# Altium schematic sheet MCU_Signals.SchDoc(MCU_Signals)
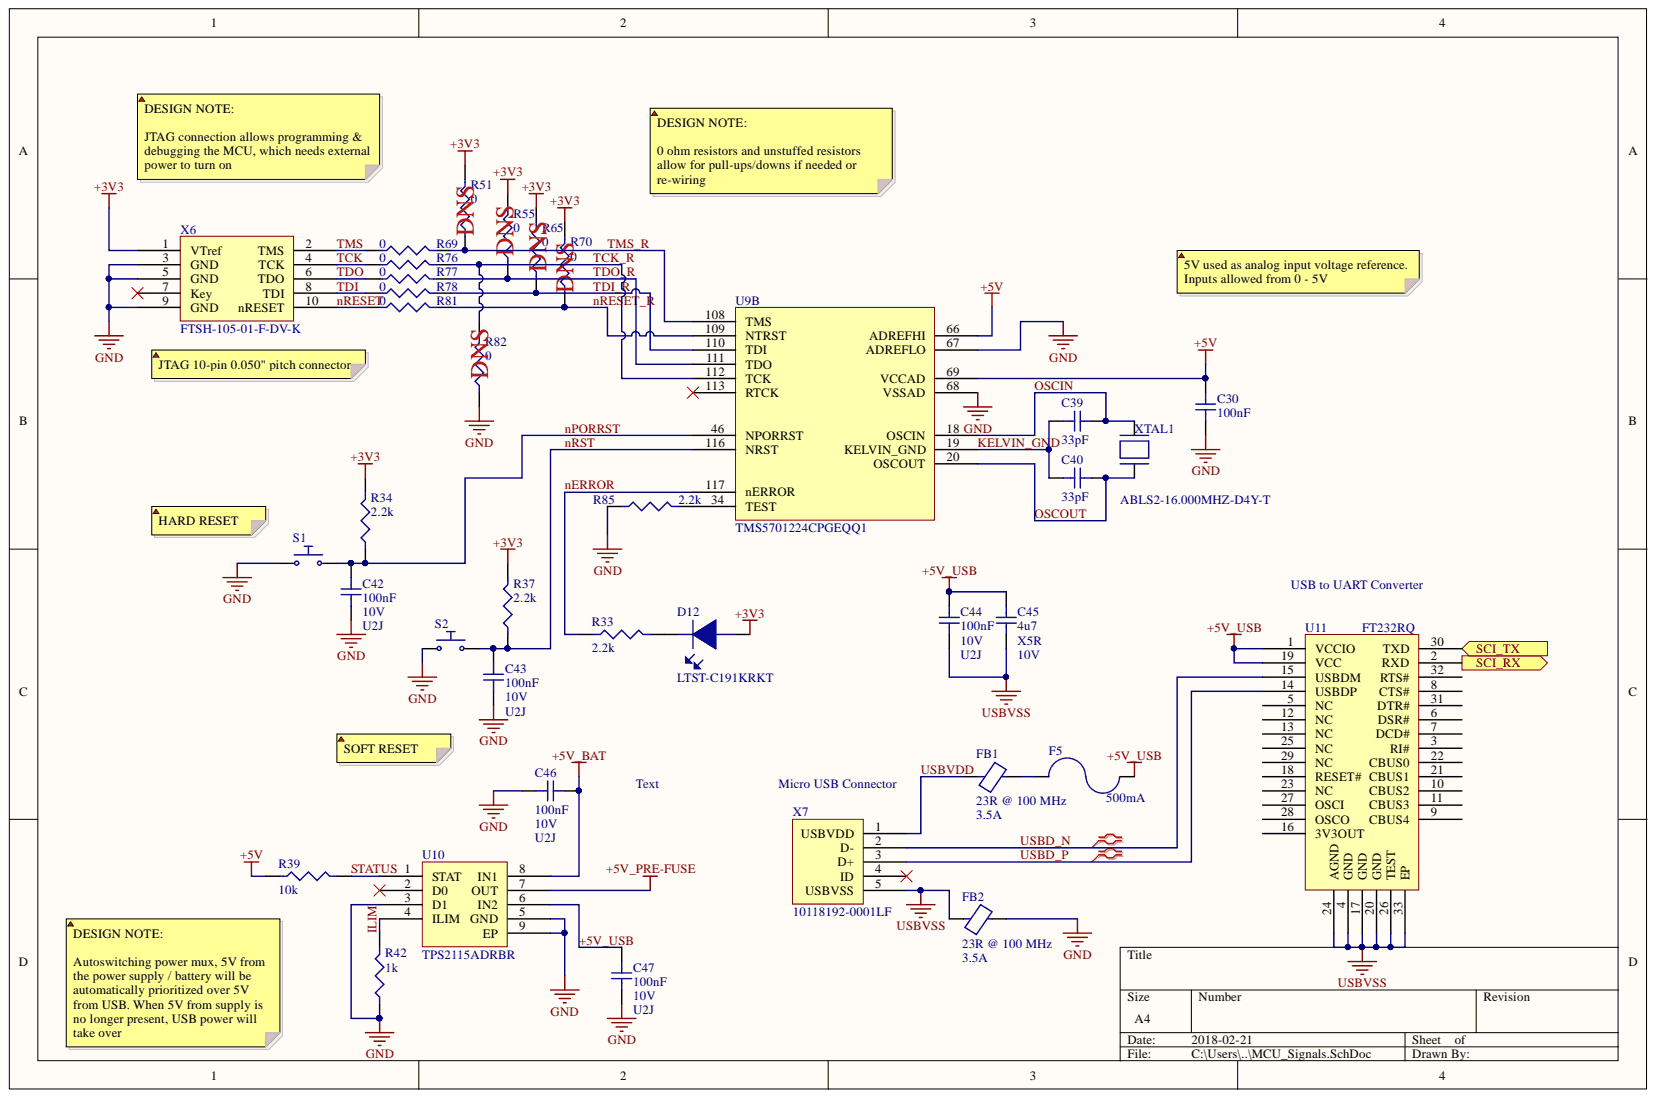
[diagram p0: MCU_Signals.SchDoc]

## Parts
C30  100nF  [C0805]
C39  33pF  [C0805]
C40  33pF  [C0805]
C42  100nF  Voltage Rating (DC): 10V  Dielectric: U2J  [C0805]
C43  100nF  Voltage Rating (DC): 10V  Dielectric: U2J  [C0805]
C44  100nF  Voltage Rating (DC): 10V  Dielectric: U2J  [C0805]
C45  4u7  Voltage Rating (DC): 10V  Dielectric: X5R  [C0805]
C46  100nF  Voltage Rating (DC): 10V  [C0805]
C47  100nF  Voltage Rating (DC): 10V  Dielectric: U2J  [C0805]
D12  LTST-C191KRKT  [LED_0603]
F5  500mA  [FUSE_0603]
FB1  23R @ 100 MHz  Max DC Current: 3.5A  Impedance: 23R  [FB_1806]
FB2  23R @ 100 MHz  Max DC Current: 3.5A  Impedance: 23R  [FB_1806]
R33  2.2k  [R0805]
R34  2.2k  [R0805]
R37  2.2k  [R0805]
R39  10k  [R0805]
R42  1k  [R0805]
R51  0  [R0805]
R55  0  [R0805]
R65  0  [R0805]
R69  0  [R0805]
R70  0  [R0805]
R76  0  [R0805]
R77  0  [R0805]
R78  0  [R0805]
R81  0  [R0805]
R82  0  [R0805]
R85  2.2k  [R0805]
S1  PTS645SM43SMTR92LFS  [PUSH_BUTTON]  (value not drawn)
S2  PTS645SM43SMTR92LFS  [PUSH_BUTTON]  (value not drawn)
U9  TMS5701224CPGEQQ1  [LQFP-144]  (part B)
U10  TPS2115ADRBR  [VSON-8]
U11  FT232RQ  [QFN-32]
X6  FTSH-105-01-F-DV-K  [JTAG_50mil_10pin]
X7  10118192-0001LF  [USB_MICRO]
XTAL1  ABLS2-16.000MHZ-D4Y-T  [XTAL]

## Ports
SCI_RX, SCI_TX

## Nets
+3V3: D12.1 R34.2 R37.2 R51.2 R55.2 R65.2 R70.2 X6.1(VTref)
+5V: C30.2 R39.1 U9.66(ADREFHI) U9.69(VCCAD)
+5V_BAT: C46.2 U10.8(IN1)
+5V_PRE-FUSE: U10.7(OUT)
GND: C30.1 C42.1 C43.1 C46.1 C47.2 FB2.2 R42.1 R82.1 R85.1 S1.1 S2.1 U9.67(ADREFLO) U9.68(VSSAD) U10.3(D1) U10.5(GND) U10.9(EP) X6.3(GND) X6.5(GND) X6.9(GND)
USBVSS: C44.1 C45.1 FB2.1 U11.4(GND) U11.17(GND) U11.20(GND) U11.24(AGND) U11.26(TEST) U11.33(EP) X7.5(USBVSS)
+5V_USB: C44.2 C45.2 C47.1 F5.2 U10.6(IN2) U11.1(VCCIO) U11.19(VCC)
ILIM: R42.2 U10.4(ILIM)
KELVIN_GND: C39.1 C40.1 U9.19(KELVIN_GND)
nERROR: R33.1 U9.117(nERROR)
nPORRST: C42.2 R34.1 S1.2 U9.46(NPORRST)
nRESET: R81.1 X6.10(nRESET)
nRESET_R: R70.1 R81.2 U9.109(NTRST)
nRST: C43.2 R37.1 S2.2 U9.116(NRST)
OSCIN: C39.2 U9.18(OSCIN) XTAL1.1
OSCOUT: C40.2 U9.20(OSCOUT) XTAL1.2
SCI_RX: U11.2(RXD) ; port SCI_RX
SCI_TX: U11.30(TXD) ; port SCI_TX
STATUS: R39.2 U10.1(STAT)
TCK: R76.1 X6.4(TCK)
TCK_R: R76.2 R82.2 U9.112(TCK)
TDI: R78.1 X6.8(TDI)
TDI_R: R65.1 R78.2 U9.110(TDI)
TDO: R77.1 X6.6(TDO)
TDO_R: R55.1 R77.2 U9.111(TDO)
TMS: R69.1 X6.2(TMS)
TMS_R: R51.1 R69.2 U9.108(TMS)
USBD_N: U11.15(USBDM) X7.2(D-)
USBD_P: U11.14(USBDP) X7.3(D+)
USBVDD: FB1.1 X7.1(USBVDD)
NetD12_2: D12.2 R33.2
NetF5_1: F5.1 FB1.2
NetR85_2: R85.2 U9.34(TEST)

Not connected: U9 113(RTCK); U10 2(D0); U11 3(RI#) 5(NC) 6(DSR#) 7(DCD#) 8(CTS#) 9(CBUS4) 10(CBUS2) 11(CBUS3) 12(NC) 13(NC) 16(3V3OUT) 18(RESET#) 21(CBUS1) 22(CBUS0) 23(NC) 25(NC) 27(OSCI) 28(OSCO) 29(NC) 31(DTR#) 32(RTS#); X6 7(Key); X7 4(ID)

## Library data of the parts
C30 = GRM21B7U1A104JA01L: CAP MLCC 100nF 10Volts U2J 5%; Manufacturer: Murata; Voltage Rating (DC): 10V; Width: 0.049inch; Voltage Rating: 10V; Tolerance: 5%; Thickness: 0.053inch; Termination: SMD/SMT; Supplier Part Number 1: 490-11592-6-ND; Supplier 1: Digi-Key; Stock 1: 6000; Radiation Hardening: No; Pricing 1: 1=0.46, 10=0.32, 100=0.192, 500=0.144, 1000=0.1216 (USD); Packaging: Tape and Reel; Package Quantity: 3000; Mount: Surface Mount; Min Operating Temperature: -55°C; Max Operating Temperature: 125°C; Manufacturer Part Number: GRM21B7U1A104JA01L; Length: 2mm; Height: 1.25mm; Dielectric: U2J; Depth: 1.25mm; ComponentLink3URL: https://datasheet.ciiva.com/11618/product-11618924.pdf; ComponentLink3Description: https://datasheet.ciiva.com/11618/product-11618924.pdf; ComponentLink2URL: https://datasheet.ciiva.com/17451/product-17451845.pdf; ComponentLink2Description: https://datasheet.ciiva.com/17451/product-17451845.pdf; ComponentLink1URL: https://datasheet.ciiva.com/5424/product-5424660.pdf; ComponentLink1Description: https://datasheet.ciiva.com/5424/product-5424660.pdf; Component Kind: Standard; Case Code (Metric): 2012; Case Code (Imperial): 0805; Case/Package: 0805; Capacitance: 100nF; Library Name: PHANTOM_LIBRARY.SchLib
C39 C40 = 885012007003: CAP MLCC 33pF 10V Ceramic Capacitor C0G, NP0 0805 (2012 Metric) 0.079" L x 0.049" W (2.00mm x 1.25mm); Manufacturer: Wurth Electronics; Tolerance: 5%; Width: 0.049inch; Voltage Rating: 10V; Thickness: 0.028inch; Supplier Part Number 1: 732-7808-1-ND; Supplier 1: Digi-Key; Stock 1: 3090; Pricing 1: 1=0.1, 50=0.068, 100=0.062, 250=0.056, 500=0.05, 1000=0.045 (USD); Packaging: Tape and Reel; Mount: Surface Mount; Min Operating Temperature: -55°C; Max Operating Temperature: 125°C; Manufacturer Part Number: 885012007003; Length: 0.079inch; Component Kind: Standard; Case Code (Metric): 2012; Case Code (Imperial): 0805; Case/Package: 0805; Capacitance: 33pF; Library Name: PHANTOM_LIBRARY.SchLib
C42-C44 C46 C47 = GRM21B7U1A104JA01L: CAP MLCC 100nF 10Volts U2J 5%; Manufacturer: Murata; Width: 0.049inch; Voltage Rating: 10V; Tolerance: 5%; Thickness: 0.053inch; Termination: SMD/SMT; Supplier Part Number 1: 490-11592-6-ND; Supplier 1: Digi-Key; Stock 1: 6000; Radiation Hardening: No; Pricing 1: 1=0.46, 10=0.32, 100=0.192, 500=0.144, 1000=0.1216 (USD); Packaging: Tape and Reel; Package Quantity: 3000; Mount: Surface Mount; Min Operating Temperature: -55°C; Max Operating Temperature: 125°C; Manufacturer Part Number: GRM21B7U1A104JA01L; Length: 2mm; Height: 1.25mm; Depth: 1.25mm; ComponentLink3URL: https://datasheet.ciiva.com/11618/product-11618924.pdf; ComponentLink3Description: https://datasheet.ciiva.com/11618/product-11618924.pdf; ComponentLink2URL: https://datasheet.ciiva.com/17451/product-17451845.pdf; ComponentLink2Description: https://datasheet.ciiva.com/17451/product-17451845.pdf; ComponentLink1URL: https://datasheet.ciiva.com/5424/product-5424660.pdf; ComponentLink1Description: https://datasheet.ciiva.com/5424/product-5424660.pdf; Component Kind: Standard; Case Code (Metric): 2012; Case Code (Imperial): 0805; Case/Package: 0805; Capacitance: 100nF; Library Name: PHANTOM_LIBRARY.SchLib
  C46: Dielectric: U2J
C45 = GRM219R61A475KE34D: CAP MLCC 4.7µF ±10% 10V X5R Ceramic Capacitor -55°C ~ 85°C Surface Mount, MLCC 0805 (2012 Metric) 0.079" L x 0.049" W (2.00mm x 1.25mm); Manufacturer: Murata; Capacitance: 4.7uF; Width: 0.049inch; Voltage Rating: 10V; Voltage: 10V; Tolerance: 10%; Thickness: 0.037inch; Termination: SMD/SMT; Supplier Part Number 1: 490-3339-6-ND; Supplier 1: Digi-Key; Stock 1: 251830; Pricing 1: 1=0.21, 10=0.152, 100=0.0716, 500=0.0502, 1000=0.0416 (USD); Packaging: Tape and Reel; Package Quantity: 4000; Mount: Surface Mount; Min Operating Temperature: -55°C; Max Operating Temperature: 85°C; Manufacturer Part Number: GRM219R61A475KE34D; Length: 2mm; Lead Free: Lead Free; Height: 0.85mm; Depth: 1.25mm; ComponentLink5URL: https://datasheet.ciiva.com/29542/product-29542668.pdf; ComponentLink5Description: https://datasheet.ciiva.com/29542/product-29542668.pdf; ComponentLink4URL: https://datasheet.ciiva.com/29542/product-29542667.pdf; ComponentLink4Description: https://datasheet.ciiva.com/29542/product-29542667.pdf; ComponentLink3URL: https://datasheet.ciiva.com/16467/product-16467258.pdf; ComponentLink3Description: https://datasheet.ciiva.com/16467/product-16467258.pdf; ComponentLink2URL: https://datasheet.ciiva.com/5414/product-5414984.pdf; ComponentLink2Description: https://datasheet.ciiva.com/5414/product-5414984.pdf; ComponentLink1URL: https://datasheet.ciiva.com/169/product-169527.pdf; ComponentLink1Description: https://datasheet.ciiva.com/169/product-169527.pdf; Component Kind: Standard; Case Code (Metric): 2012; Case Code (Imperial): 0805; Case/Package: 0805; Library Name: PHANTOM_LIBRARY.SchLib
D12 = LTST-C191KRKT: LED RED CLEAR 0603 SMD; Manufacturer: Vishay Lite-On; Wavelength: 639nm; Voltage: 2V; Viewing Angle: 130°; Test Current: 20mA; Reverse Voltage: 5V; Power Rating: 75mW; Number of LEDs: 1; Luminous Intensity: 54mcd; Lens Style: Clear,Diffused,Colorless,Rectangular; Lens Colour: Colorless; Forward Current: 30mA; Dominant Wavelength: 631nm; Width: 0.8mm; Supplier Part Number 1: 160-1447-1-ND; Supplier 1: Digi-Key; Stock 1: 684119; Radiation Hardening: No; Pricing 1: 1=0.29, 10=0.211, 25=0.1556, 100=0.1111, 250=0.0756, 500=0.0667, 1000=0.0522, 2500=0.0511 (USD); Power Dissipation: 75mW; Packaging: Tape and Reel; Package Quantity: 1; Number of Pins: 2; Number of Elements: 1; Mount: Surface Mount; Min Operating Temperature: -55°C; Max Operating Temperature: 85°C; Manufacturer Part Number: LTST-C191KRKT; Lens Transparency: Clear; Length: 1.6mm; Lead Free: Lead Free; Illumination Colour: Red; Height: 0.55mm; Forward Voltage: 2V; Depth: 0.8mm; ComponentLink7URL: https://datasheet.ciiva.com/12400/lite-on-ltst-c191krkt-190135-12400910.pdf; ComponentLink7Description: https://datasheet.ciiva.com/12400/lite-on-ltst-c191krkt-190135-12400910.pdf; ComponentLink6URL: https://datasheet.ciiva.com/15117/getdatasheetpartid-141922-15117737.pdf; ComponentLink6Description: https://datasheet.ciiva.com/15117/getdatasheetpartid-141922-15117737.pdf; ComponentLink5URL: https://datasheet.ciiva.com/2109/s-110-ltst-c191krkt-2109972.pdf; ComponentLink5Description: https://datasheet.ciiva.com/2109/s-110-ltst-c191krkt-2109972.pdf; ComponentLink4URL: https://datasheet.ciiva.com/16212/ltst-c191krkt-16212419.pdf; ComponentLink4Description: https://datasheet.ciiva.com/16212/ltst-c191krkt-16212419.pdf; ComponentLink3URL: https://datasheet.ciiva.com/2109/s-110-ltst-c191krkt-2109971.pdf; ComponentLink3Description: https://datasheet.ciiva.com/2109/s-110-ltst-c191krkt-2109971.pdf; ComponentLink2URL: https://datasheet.ciiva.com/2109/s-110-ltst-c191krkt-2109970.pdf; ComponentLink2Description: https://datasheet.ciiva.com/2109/s-110-ltst-c191krkt-2109970.pdf; ComponentLink1URL: https://datasheet.ciiva.com/11773/0900766b80dc02b2-11773601.pdf; ComponentLink1Description: https://datasheet.ciiva.com/11773/0900766b80dc02b2-11773601.pdf; Component Kind: Standard; Colour: Red; Case Code (Metric): 1608; Case Code (Imperial): 0603; Case/Package: 0603; Library Name: PHANTOM_LIBRARY.SchLib
F5 = ERB-RE0R50V: FUSE_0.5A SMD 0603, 0.5A; Manufacturer: Panasonic ECG; Voltage Rating (DC): 32V; Fuse Type: Board Mount (Cartridge Style Excluded); DC Cold Resistance: 330mR; Body Material: Alumina; Approvals: UL; Width: 0.8mm; Voltage Rating: 32V; Supplier Part Number 1: P15127CT-ND; Supplier 1: Digi-Key; Stock 1: 15715; REACH SVHC: No SVHC; Radiation Hardening: No; Pricing 1: 1=0.29, 5=0.284, 10=0.272, 50=0.2424, 100=0.2257, 250=0.2006, 500=0.1672, 1000=0.1588 (USD); Packaging: Tape and Reel; Package Quantity: 5000; Number of Pins: 2; Mount: Surface Mount; Min Operating Temperature: -40°C; Max Voltage Rating (DC): 32V; Max Operating Temperature: 125°C; Manufacturer Part Number: ERB-RE0R50V; Length: 1.6mm; Height: 0.54mm; Fuse Current: 500mA; Depth: 0.8mm; Current Rating: 500mA; Current: 500mA; ComponentLink9URL: https://datasheet.ciiva.com/46376/1328695-46376557.pdf; ComponentLink9Description: https://datasheet.ciiva.com/46376/1328695-46376557.pdf; ComponentLink8URL: https://datasheet.ciiva.com/30017/afa0000ce6-30017405.pdf; ComponentLink8Description: https://datasheet.ciiva.com/30017/afa0000ce6-30017405.pdf; ComponentLink7URL: https://datasheet.ciiva.com/46388/1328695-46388892.pdf; ComponentLink7Description: https://datasheet.ciiva.com/46388/1328695-46388892.pdf; ComponentLink6URL: https://datasheet.ciiva.com/5813/1328695-5813626.pdf; ComponentLink6Description: https://datasheet.ciiva.com/5813/1328695-5813626.pdf; ComponentLink5URL: https://datasheet.ciiva.com/11337/afa0000ce6-11337817.pdf; ComponentLink5Description: https://datasheet.ciiva.com/11337/afa0000ce6-11337817.pdf; ComponentLink4URL: https://datasheet.ciiva.com/25619/0900766b810c2f76-25619783.pdf; ComponentLink4Description: https://datasheet.ciiva.com/25619/0900766b810c2f76-25619783.pdf; ComponentLink3URL: https://datasheet.ciiva.com/299/afa0000ce6-299094.pdf; ComponentLink3Description: https://datasheet.ciiva.com/299/afa0000ce6-299094.pdf; ComponentLink2URL: https://datasheet.ciiva.com/299/afa0000ce6-299093.pdf; ComponentLink2Description: https://datasheet.ciiva.com/299/afa0000ce6-299093.pdf; ComponentLink1URL: https://datasheet.ciiva.com/299/afa0000ce6-299092.pdf; ComponentLink1Description: https://datasheet.ciiva.com/299/afa0000ce6-299092.pdf; Component Kind: Standard; Case Code (Metric): 1608; Case Code (Imperial): 0603; Case/Package: 0603; Library Name: PHANTOM_LIBRARY.SchLib
FB1 FB2 = FBMJ4516HL230NTV: FERRITE BEAD Ind Chip Bead Multi-Layer 23Ohm 30% 100MHz Ferrite 3.5A 1806 T/R; Manufacturer: Taiyo Yuden; Resistance: 180623R; Number of Lines: 1; DC Resistance (DCR): 14mR; Width: 1.6mm; Tolerance: 30%; Test Frequency: 100MHz; Termination: SMD/SMT; Supplier Part Number 1: 587-3857-1-ND; Supplier 1: Digi-Key; Stock 1: 1819; Pricing 1: 1=0.26, 10=0.202, 25=0.1676, 50=0.1444, 100=0.1299, 250=0.1097, 500=0.0982, 1000=0.0837 (USD); Packaging: Tape and Reel; Package Quantity: 2000; Mount: Surface Mount; Min Operating Temperature: -40°C; Max Operating Temperature: 125°C; Max Current Rating: 3.5A; Manufacturer Part Number: FBMJ4516HL230NTV; Length: 0.177inch; Height: 1.1mm; Depth: 1.6mm; Core Material: Ferrite; ComponentLink3URL: https://www.yuden.co.jp/ut/product/category/emisuppression/FBMJ4516HL230NTV_SS.pdf; ComponentLink3Description: https://www.yuden.co.jp/ut/product/category/emisuppression/FBMJ4516HL230NTV_SS.pdf; ComponentLink2URL: http://ds.yuden.co.jp/TYCOMPAS/ut/detail.do?productNo=FBMJ4516HL230NTV&fileName=FBMJ4516HL230NTV_SS&mode=specSheetDownload; ComponentLink2Description: http://ds.yuden.co.jp/TYCOMPAS/ut/detail.do?productNo=FBMJ4516HL230NTV&fileName=FBMJ4516HL230NTV_SS&mode=specSheetDownload; ComponentLink1URL: http://www.mouser.com/ds/2/396/chipbeads01_e-559265.pdf; ComponentLink1Description: http://www.mouser.com/ds/2/396/chipbeads01_e-559265.pdf; Component Kind: Standard; Case Code (Metric): 4516; Case Code (Imperial): 1806; Case/Package: 1806; Library Name: PHANTOM_LIBRARY.SchLib
R33 R34 R37 R85 = RC0805JR-072K2L: RES SMD 2.2K OHM 5% 1/8W; Manufacturer: Yageo; Voltage Rating: 150V; Temperature Coefficient: 100ppm/°C; Resistance: 2.2kR; Power Rating: 125mW; Tolerance: 5%; Termination: SMD/SMT; Supplier Part Number 1: 311-2.2KARCT-ND; Supplier 1: Digi-Key; Stock 1: 810523; REACH SVHC: Unknown; Radiation Hardening: No; Pricing 1: 1=0.1, 10=0.019, 100=0.0076, 1000=0.0034, 2500=0.003 (USD); Packaging: Tape and Reel; Min Operating Temperature: -55°C; Military Standard: Not; Max Operating Temperature: 155°C; Manufacturer Part Number: RC0805JR-072K2L; Length: 2mm; Lead Free: Lead Free; Depth: 1.25mm; Contact Plating: Tin,Lead,Matte; Composition: Thick Film; ComponentLink3URL: https://datasheet.ciiva.com/7941/442941-7941935.pdf; ComponentLink3Description: https://datasheet.ciiva.com/7941/442941-7941935.pdf; ComponentLink2URL: https://datasheet.ciiva.com/15190/getdatasheetpartid-251869-15190044.pdf; ComponentLink2Description: https://datasheet.ciiva.com/15190/getdatasheetpartid-251869-15190044.pdf; ComponentLink1URL: https://datasheet.ciiva.com/12667/rc0805-12667397.pdf; ComponentLink1Description: https://datasheet.ciiva.com/12667/rc0805-12667397.pdf; Component Kind: Standard; Case Code (Metric): 2012; Case Code (Imperial): 0805; Case/Package: 0805; Library Name: PHANTOM_LIBRARY.SchLib
R39 = RC0805FR-071KL: RES SMD 10K OHM 1% 1/8W 0805; Manufacturer: Yageo Phycomp; Voltage Rating (DC): 150V; Temperature Coefficient: 100ppm/°C; Resistance: 1KR; Power Rating: 125mW; Width: 1.25mm; Tolerance: 1%; Supplier 1: Digi-Key; Stock 1: 5527973; REACH SVHC: No SVHC; Pricing 1: 1=0.1, 10=0.022, 100=0.0088, 1000=0.004, 2500=0.0034 (USD); Packaging: Cut Tape; Number of Pins: 2; Min Operating Temperature: -55°C; Max Operating Temperature: 155°C; Manufacturer Part Number: RC0805FR-071KL; Length: 2mm; Lead Free: Lead Free; Height: 0.5mm; Depth: 1.25mm; Component Kind: Standard; Case/Package: 0805; Library Name: PHANTOM_LIBRARY.SchLib
R42 = ERA-6AEB102V: RES SMD 1K OHM 0805 1K Ohm 0.1% 0.125W(1/8W) ±25ppm/C Molded SMD Automotive Punched T/R; Manufacturer: Panasonic ECG; Voltage Rating: 100V; Temperature Coefficient: 25ppm/°C; Resistance: 1kR; Power Rating: 125mW; Width: 1.25mm; Tolerance: 0.1%; Termination: SMD/SMT; Supplier Part Number 1: P1.0KDACT-ND; Supplier 1: Digi-Key; Stock 1: 506583; REACH SVHC: No SVHC; Radiation Hardening: No; Pricing 1: 1=0.36, 10=0.302, 100=0.1182, 1000=0.0495, 2500=0.0454 (USD); Packaging: Tape and Reel; Package Quantity: 5000; Number of Terminations: 2; Number of Pins: 2; Mount: Surface Mount; Min Operating Temperature: -55°C; Military Standard: Not; Max Power Dissipation: 125mW; Max Operating Temperature: 155°C; Manufacturer Part Number: ERA-6AEB102V; Length: 2mm; Height: 0.5mm; Depth: 1.25mm; Contact Plating: Tin; Composition: Thin Film; ComponentLink13URL: https://datasheet.ciiva.com/29184/453027-da-01-en-widerstand-0805-era-29184108.pdf; ComponentLink13Description: https://datasheet.ciiva.com/29184/453027-da-01-en-widerstand-0805-era-29184108.pdf; ComponentLink12URL: https://datasheet.ciiva.com/7942/485442-7942415.pdf; ComponentLink12Description: https://datasheet.ciiva.com/7942/485442-7942415.pdf; ComponentLink11URL: https://datasheet.ciiva.com/22783/485442-22783806.pdf; ComponentLink11Description: https://datasheet.ciiva.com/22783/485442-22783806.pdf; ComponentLink10URL: https://datasheet.ciiva.com/16201/aoa0000ce26-16201486.pdf; ComponentLink10Description: https://datasheet.ciiva.com/16201/aoa0000ce26-16201486.pdf; ComponentLink9URL: https://datasheet.ciiva.com/7942/485442-7942414.pdf; ComponentLink9Description: https://datasheet.ciiva.com/7942/485442-7942414.pdf; ComponentLink8URL: https://datasheet.ciiva.com/43457/485442-43457803.pdf; ComponentLink8Description: https://datasheet.ciiva.com/43457/485442-43457803.pdf; ComponentLink7URL: https://datasheet.ciiva.com/43455/485442-43455257.pdf; ComponentLink7Description: https://datasheet.ciiva.com/43455/485442-43455257.pdf; ComponentLink6URL: https://datasheet.ciiva.com/24025/aoa0000ce26-197354-24025849.pdf; ComponentLink6Description: https://datasheet.ciiva.com/24025/aoa0000ce26-197354-24025849.pdf; ComponentLink5URL: https://datasheet.ciiva.com/16782/70068849-16782808.pdf; ComponentLink5Description: https://datasheet.ciiva.com/16782/70068849-16782808.pdf; ComponentLink4URL: https://datasheet.ciiva.com/9198/aoa0000ce26-9198984.pdf; ComponentLink4Description: https://datasheet.ciiva.com/9198/aoa0000ce26-9198984.pdf; ComponentLink3URL: https://datasheet.ciiva.com/48536/era-eng-tds-48536727.pdf; ComponentLink3Description: https://datasheet.ciiva.com/48536/era-eng-tds-48536727.pdf; ComponentLink2URL: https://datasheet.ciiva.com/30057/54aoa0000ce26-30057591.pdf; ComponentLink2Description: https://datasheet.ciiva.com/30057/54aoa0000ce26-30057591.pdf; ComponentLink1URL: https://datasheet.ciiva.com/11811/0900766b80beefcc-11811155.pdf; ComponentLink1Description: https://datasheet.ciiva.com/11811/0900766b80beefcc-11811155.pdf; Component Kind: Standard; Case Code (Metric): 2012; Case Code (Imperial): 0805; Case/Package: 0805; Library Name: PHANTOM_LIBRARY.SchLib
R51 R55 R65 R69 R70 R76-R78 R81 R82 = RC0805JR-070RL: RES SMD 0 OHM 1/8W 0805; Manufacturer: Yageo; Voltage Rating (DC): 150V; Tolerance: 5%; Temperature Coefficient: 200ppm/°C; Resistance: 0mR; Power Rating: 125mW; Width: 1.25mm; Voltage Rating: 150V; Termination: SMD/SMT; Supplier Part Number 1: 311-0.0ARDKR-ND; Supplier 1: Digi-Key; Stock 1: 5922085; REACH SVHC: Unknown; Radiation Hardening: No; Pricing 1: 1=0.1, 10=0.018, 25=0.0128, 50=0.0096, 100=0.0071, 250=0.0054, 500=0.0044, 1000=0.0032 (USD); Packaging: Tape and Reel; Number of Pins: 2; Min Operating Temperature: -55°C; Military Standard: Not; Max Operating Temperature: 155°C; Manufacturer Part Number: RC0805JR-070RL; Length: 2mm; Lead Free: Lead Free; Height: 0.5mm; Depth: 1.25mm; Contact Plating: Tin,Matte; Composition: Thick Film; ComponentLink5URL: https://datasheet.ciiva.com/15190/getdatasheetpartid-330685-15190028.pdf; ComponentLink5Description: https://datasheet.ciiva.com/15190/getdatasheetpartid-330685-15190028.pdf; ComponentLink4URL: https://datasheet.ciiva.com/7941/1738694-7941863.pdf; ComponentLink4Description: https://datasheet.ciiva.com/7941/1738694-7941863.pdf; ComponentLink3URL: https://datasheet.ciiva.com/11819/0900766b8106beab-11819430.pdf; ComponentLink3Description: https://datasheet.ciiva.com/11819/0900766b8106beab-11819430.pdf; ComponentLink2URL: https://datasheet.ciiva.com/7941/1738694-7941862.pdf; ComponentLink2Description: https://datasheet.ciiva.com/7941/1738694-7941862.pdf; ComponentLink1URL: https://datasheet.ciiva.com/7941/1738694-7941861.pdf; ComponentLink1Description: https://datasheet.ciiva.com/7941/1738694-7941861.pdf; Component Kind: Standard; Case Code (Metric): 2012; Case Code (Imperial): 0805; Case/Package: 0805; Library Name: PHANTOM_LIBRARY.SchLib
S1 S2 = PTS645SM43SMTR92LFS: SWITCH TACTILE SPST-NO 0.05A 12V; Manufacturer: ITT C&K; Throw Configuration: SPST; Switch Function: Off-Mom; Operating Force: 160gf; Mechanical Life: 100000Cycles; Max Current Rating: 50mA; Illumination Type: Non-Illuminated,Illuminated; Contact Resistance: 100mR; Actuator Material: Nylon,Polyamide; Actuator Length: 0.85mm; Voltage Rating (DC): 12V; Termination: Gull Wing; Supplier Part Number 1: CKN9112CT-ND; Supplier 1: Digi-Key; Stock 1: 188827; REACH SVHC: No SVHC; Pricing 1: 1=0.16, 10=0.157, 25=0.1516, 50=0.1462, 100=0.1381, 250=0.13, 500=0.1245 (USD); Packaging: Tape and Reel; Package Quantity: 1000; Orientation: Straight; Mount: Surface Mount; Min Operating Temperature: -20°C; Max Voltage Rating (DC): 12V; Max Operating Temperature: 60°C; Manufacturer Part Number: PTS645SM43SMTR92LFS; Length: 6mm; Lead Free: Lead Free; Depth: 9mm; Current Rating: 50mA; Contact Plating: Silver; Contact Material: Silver; ComponentLink5URL: https://datasheet.ciiva.com/29931/getdatasheetpartid-764615-29931183.pdf; ComponentLink5Description: https://datasheet.ciiva.com/29931/getdatasheetpartid-764615-29931183.pdf; ComponentLink4URL: https://datasheet.ciiva.com/14920/pts645-14920735.pdf; ComponentLink4Description: https://datasheet.ciiva.com/14920/pts645-14920735.pdf; ComponentLink3URL: https://datasheet.ciiva.com/14920/pts645-14920734.pdf; ComponentLink3Description: https://datasheet.ciiva.com/14920/pts645-14920734.pdf; ComponentLink2URL: https://datasheet.ciiva.com/14920/pts645-14920733.pdf; ComponentLink2Description: https://datasheet.ciiva.com/14920/pts645-14920733.pdf; ComponentLink1URL: https://datasheet.ciiva.com/29903/getdatasheetpartid-786341-29903846.pdf; ComponentLink1Description: https://datasheet.ciiva.com/29903/getdatasheetpartid-786341-29903846.pdf; Component Kind: Standard; Colour: Black; Case/Package: SMD/SMT; Library Name: PHANTOM_LIBRARY.SchLib
U9 = TMS5701224CPGEQQ1: ARM CORTEX R4 LOCK STEP HERCULES MCU; Manufacturer: Texas Instruments; Watchdog Timer: Yes; RAM Size: 192KB; Peripherals: POR,PWM,WDT,DMA; Oscillator Type: External; Number of Timers/Counters: 2; Number of Programmable I/O: 64; Memory Type: FLASH; Memory Size: 1.25MB; Max Frequency: 160MHz; Interface: I2C,SPI,CAN,UART,USART,LIN,SCI,EBI/EMI; Density: 1310720B; Supplier Part Number 1: 296-47200-ND; Supplier 1: Digi-Key; Stock 1: 113; Pricing 1: 1=22.12, 10=20.402, 100=17.4222, 500=15.8176 (USD); Packaging: Tray; Number of Pins: 144; Number of I/Os: 64; Number of GPIO: 64; Min Operating Temperature: -40°C; Max Operating Temperature: 125°C; Manufacturer Part Number: TMS5701224CPGEQQ1; Lead Free: Lead Free; Frequency: 160MHz; Core Architecture: ARM; ComponentLink3URL: http://www.ti.com/general/docs/lit/getliterature.tsp?genericPartNumber=TMS570LS1224&&fileType=pdf; ComponentLink3Description: http://www.ti.com/general/docs/lit/getliterature.tsp?genericPartNumber=TMS570LS1224&&fileType=pdf; ComponentLink2URL: http://www.ti.com/lit/gpn/tms570ls1224; ComponentLink2Description: http://www.ti.com/lit/gpn/tms570ls1224; ComponentLink1URL: http://www.ti.com/lit/pdf/spnu515; ComponentLink1Description: http://www.ti.com/lit/pdf/spnu515; Component Kind: Standard; Case/Package: LQFP; Library Name: PHANTOM_LIBRARY.SchLib
U10 = TPS2115ADRBR: IC OR CTRLR SRC SELECT 8SON; Manufacturer: Texas Instruments; Turn-On Delay Time: 1ms; Turn-Off Delay Time: 5ms; Output Current: 1.25A; Operating Supply Voltage: 5.5V; Operating Supply Current: 55uA; On-State Resistance: 150mR; Number of Outputs: 1; Number of Inputs: 2; Min Input Voltage: 2.8V; Max Power Dissipation: 2.5W; Weight: 0.000847oz; Supplier Part Number 1: 296-23394-1-ND; Supplier 1: Digi-Key; Stock 1: 13497; REACH SVHC: No SVHC; Radiation Hardening: No; Pricing 1: 1=2.01, 10=1.801, 100=1.448, 500=1.1897, 1000=0.9857 (USD); Packaging: Tape and Reel; Package Quantity: 3000; Number of Pins: 8; Min Operating Temperature: -40°C; Max Supply Current: 55uA; Max Output Current: 1.25A; Max Operating Temperature: 85°C; Max Input Voltage: 5.5V; Manufacturer Part Number: TPS2115ADRBR; Lead Free: Lead Free; Current - Output 2: 1.25A; ComponentLink5URL: https://datasheet.ciiva.com/1960/tps2115a-1960794.pdf; ComponentLink5Description: https://datasheet.ciiva.com/1960/tps2115a-1960794.pdf; ComponentLink4URL: https://datasheet.ciiva.com/1960/tps2115a-1960793.pdf; ComponentLink4Description: https://datasheet.ciiva.com/1960/tps2115a-1960793.pdf; ComponentLink3URL: https://datasheet.ciiva.com/3232/tps2115a-3232536.pdf; ComponentLink3Description: https://datasheet.ciiva.com/3232/tps2115a-3232536.pdf; ComponentLink2URL: https://datasheet.ciiva.com/1960/tps2115a-1960792.pdf; ComponentLink2Description: https://datasheet.ciiva.com/1960/tps2115a-1960792.pdf; ComponentLink1URL: https://datasheet.ciiva.com/15109/getdatasheetpartid-221489-15109265.pdf; ComponentLink1Description: https://datasheet.ciiva.com/15109/getdatasheetpartid-221489-15109265.pdf; Component Kind: Standard; Case/Package: TSSOP; Library Name: PHANTOM_LIBRARY.SchLib
U11 = FT232RQ-REEL: IC USB FS SERIAL UART 32-QFN; Manufacturer: FTDI; Operating Supply Voltage: 5V; Operating Supply Current: 15mA; Number of Channels: 1; Min Supply Voltage: 3.3V; Max Supply Voltage: 5.25V; Data Rate: 12Mbps; Width: 5mm; Weight: 0.006653oz; Supplier Part Number 1: 768-1008-1-ND; Supplier 1: Digi-Key; Stock 1: 97831; Radiation Hardening: No; Pricing 1: 1=4.5, 10=4.05, 100=3.65, 250=3.25, 500=2.85, 1000=2.65, 2500=2.35 (USD); Packaging: Tape and Reel; Package Quantity: 6000; Number of Pins: 32; Mount: Surface Mount; Min Operating Temperature: -40°C; Max Operating Temperature: 85°C; Manufacturer Part Number: FT232RQ-REEL; Length: 5mm; Lead Free: Lead Free; Interface: UART; ComponentLink7URL: https://datasheet.ciiva.com/26841/ft232rq-reel-26841082.pdf; ComponentLink7Description: https://datasheet.ciiva.com/26841/ft232rq-reel-26841082.pdf; ComponentLink6URL: https://datasheet.ciiva.com/4787/ds-ft232r-4787663.pdf; ComponentLink6Description: https://datasheet.ciiva.com/4787/ds-ft232r-4787663.pdf; ComponentLink5URL: https://datasheet.ciiva.com/15150/getdatasheetpartid-644721-15150509.pdf; ComponentLink5Description: https://datasheet.ciiva.com/15150/getdatasheetpartid-644721-15150509.pdf; ComponentLink4URL: https://datasheet.ciiva.com/16438/ds-ft232r-16438555.pdf; ComponentLink4Description: https://datasheet.ciiva.com/16438/ds-ft232r-16438555.pdf; ComponentLink3URL: https://datasheet.ciiva.com/1787/ds-ft232r-1787042.pdf; ComponentLink3Description: https://datasheet.ciiva.com/1787/ds-ft232r-1787042.pdf; ComponentLink2URL: https://datasheet.ciiva.com/1787/ds-ft232r-1787041.pdf; ComponentLink2Description: https://datasheet.ciiva.com/1787/ds-ft232r-1787041.pdf; ComponentLink1URL: https://datasheet.ciiva.com/1505/1647396-1505445.pdf; ComponentLink1Description: https://datasheet.ciiva.com/1505/1647396-1505445.pdf; Component Kind: Standard; Case/Package: QFN EP; Library Name: PHANTOM_LIBRARY.SchLib
X6 = FTSH-105-01-F-DV-K: CONN_JTAG_10pin .050 Connector Dual Header 10 Position Surface Mount; Manufacturer: Samtec; Row Spacing: 0.05inch; Pitch: 1.27mm; Number of Positions: 10; Max Current Rating: 1.75A; Connector Type: Male Pin; Termination: Solder; Supplier Part Number 1: SAM8796-ND; Supplier 1: Digi-Key; Stock 1: 18199; Radiation Hardening: No; Pricing 1: 1=2.9, 10=2.784, 100=2.32, 500=1.972, 1000=1.682, 5000=1.508 (USD); Packaging: Rail/Tube; Package Quantity: 1; Orientation: Straight; Number of Rows: 2; Number of Contacts: 10; Mount: Surface Mount; Min Operating Temperature: -55°C; Max Operating Temperature: 125°C; Manufacturer Part Number: FTSH-105-01-F-DV-K; Length: 15.2mm; Lead Free: Lead Free; Housing Material: Polymer; Housing Colour: Black; Height: 3.3mm; Depth: 3.43mm; Contact Plating: Nickel,Gold; Contact Mating Length: 0.12inch; Contact Material: Bronze,Copper; ComponentLink5URL: https://datasheet.ciiva.com/15195/getdatasheetpartid-133463-15195260.pdf; ComponentLink5Description: https://datasheet.ciiva.com/15195/getdatasheetpartid-133463-15195260.pdf; ComponentLink4URL: https://datasheet.ciiva.com/16657/ftsh-mt-16657371.pdf; ComponentLink4Description: https://datasheet.ciiva.com/16657/ftsh-mt-16657371.pdf; ComponentLink3URL: https://datasheet.ciiva.com/10245/ftsh-mt-10245273.pdf; ComponentLink3Description: https://datasheet.ciiva.com/10245/ftsh-mt-10245273.pdf; ComponentLink2URL: https://datasheet.ciiva.com/738/ftsh-1xx-xx-xxx-dv-xxx-mkt-738998.pdf; ComponentLink2Description: https://datasheet.ciiva.com/738/ftsh-1xx-xx-xxx-dv-xxx-mkt-738998.pdf; ComponentLink1URL: https://datasheet.ciiva.com/19461/2046846-19461026.pdf; ComponentLink1Description: https://datasheet.ciiva.com/19461/2046846-19461026.pdf; Component Kind: Standard; Colour: Black; Library Name: PHANTOM_LIBRARY.SchLib
X7 = 10118192-0001LF: CONN_USB - micro B USB 2.0 Receptacle Connector 5 Position Surface Mount, Right Angle, Horizontal; Manufacturer: Amphenol FCI; Voltage Rating: 100V; USB Standard: USB 2.0; Pitch: 0.65mm; Number of Ports: 1; Number of Contacts: 5; Insulation Resistance: 1GR; Current Rating: 1.8A; Connector Type: USB,Receptacle; Termination: Solder; Supplier Part Number 1: 609-4613-1-ND; Supplier 1: Digi-Key; Stock 1: 197826; REACH SVHC: No SVHC; Radiation Hardening: No; Pricing 1: 1=0.46, 10=0.437, 25=0.3744, 50=0.318, 100=0.3056, 250=0.2744, 500=0.262 (USD); Packaging: Tape and Reel; Package Quantity: 1400; Orientation: Right Angle; Number of Terminals: 5; Mount: Surface Mount,Horizontal; Min Operating Temperature: -55°C; Max Voltage Rating (AC): 100V; Max Operating Temperature: 85°C; Manufacturer Part Number: 10118192-0001LF; Length: 7.86mm; Lead Free: Lead Free; Housing Material: Polymer; Gender: Receptacle; Flammability Rating: UL94 V-0; Depth: 5.57mm; Contact Plating: Nickel,Gold; Contact Material: Copper Alloy; ComponentLink3URL: https://datasheet.ciiva.com/4598/10118192ac-197249-4598796.pdf; ComponentLink3Description: https://datasheet.ciiva.com/4598/10118192ac-197249-4598796.pdf; ComponentLink2URL: https://datasheet.ciiva.com/851/10118192-851112.pdf; ComponentLink2Description: https://datasheet.ciiva.com/851/10118192-851112.pdf; ComponentLink1URL: https://datasheet.ciiva.com/26853/getdatasheetpartid-418041-26853891.pdf; ComponentLink1Description: https://datasheet.ciiva.com/26853/getdatasheetpartid-418041-26853891.pdf; Component Kind: Standard; Colour: Black; Library Name: PHANTOM_LIBRARY.SchLib
XTAL1 = ABLS2-16.000MHZ-D4Y-T: XTAL 16MHz ±30ppm Crystal 18pF 40 Ohm -40°C ~ 85°C  Surface Mount HC49/US; Manufacturer: Abracon; Series Resistance: 40R; Width: 0.185inch; Tolerance: 30ppm; Termination: SMD/SMT; Supplier Part Number 1: 535-9875-1-ND; Supplier 1: Digi-Key; Stock 1: 14083; REACH SVHC: Unknown; Pricing 1: 1=0.46, 10=0.385, 50=0.3466, 100=0.308, 500=0.2926 (USD); Packaging: Tape and Reel; Package Quantity: 1000; Mount: Surface Mount; Min Operating Temperature: -40°C; Max Operating Temperature: 85°C; Manufacturer Part Number: ABLS2-16.000MHZ-D4Y-T; Load Capacitance: 18pF; Length: 11.05mm; Lead Free: Lead Free; Height: 0.13inch; Frequency Tolerance: 30ppm; Frequency Stability: 30ppm; Frequency: 16MHz; ESR (Equivalent Series Resistance): 40R; Depth: 4.8mm; ComponentLink4URL: https://datasheet.ciiva.com/854/abls2-854399.pdf; ComponentLink4Description: https://datasheet.ciiva.com/854/abls2-854399.pdf; ComponentLink3URL: https://datasheet.ciiva.com/15116/getdatasheetpartid-719900-15116780.pdf; ComponentLink3Description: https://datasheet.ciiva.com/15116/getdatasheetpartid-719900-15116780.pdf; ComponentLink2URL: https://datasheet.ciiva.com/854/abls2-854401.pdf; ComponentLink2Description: https://datasheet.ciiva.com/854/abls2-854401.pdf; ComponentLink1URL: https://datasheet.ciiva.com/854/abls2-854400.pdf; ComponentLink1Description: https://datasheet.ciiva.com/854/abls2-854400.pdf; Component Kind: Standard; Library Name: PHANTOM_LIBRARY.SchLib
